# Altium schematic sheet 3) HALL EFFECT.SchDoc("3) HALL EFFECT")
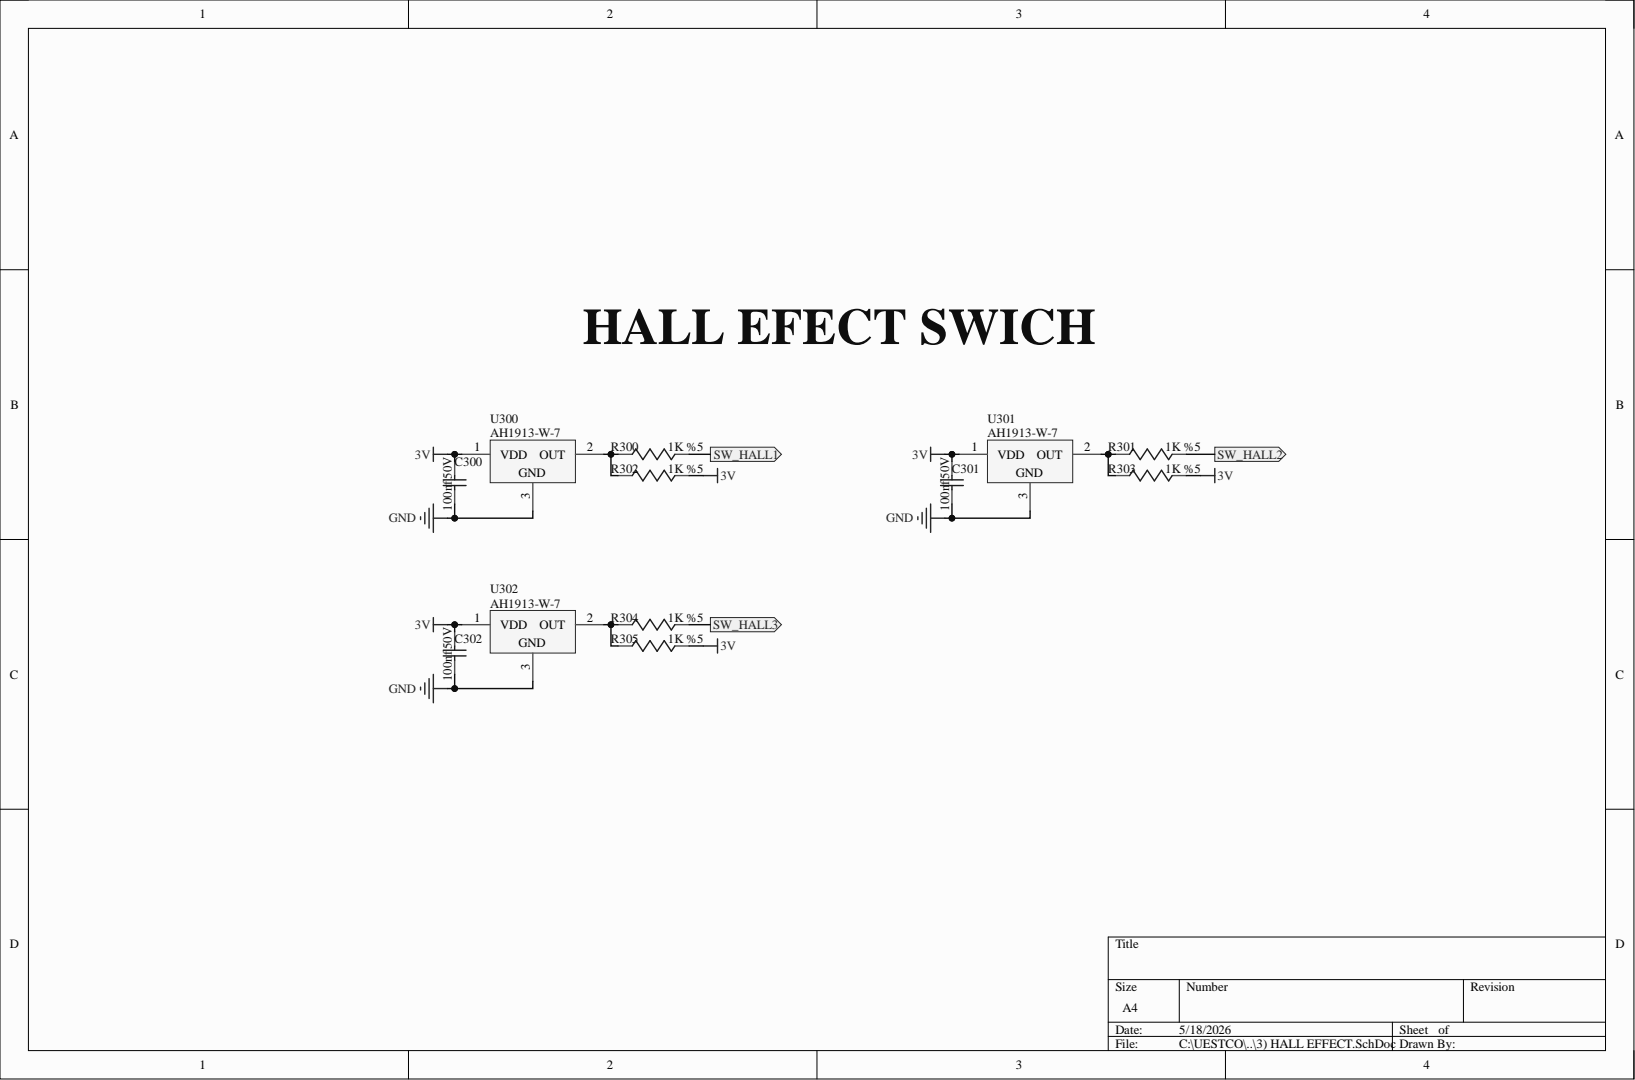
[diagram p0: 3) HALL EFFECT.SchDoc]

## Parts
C300  100nf 50V  [C0402]
C301  100nf 50V  [C0402]
C302  100nf 50V  [C0402]
R300  1K %5  [R0402]
R301  1K %5  [R0402]
R302  1K %5  [R0402]
R303  1K %5  [R0402]
R304  1K %5  [R0402]
R305  1K %5  [R0402]
U300  AH1913-W-7  [SOT23]
U301  AH1913-W-7  [SOT23]
U302  AH1913-W-7  [SOT23]

## Ports
SW_HALL1, SW_HALL2, SW_HALL3

## Nets
3V: C300.1 C301.1 C302.1 R302.1 R303.1 R305.1 U300.1 U301.1 U302.1
GND: C300.2 C301.2 C302.2 U300.3 U301.3 U302.3
SW_HALL1: R300.1 ; port SW_HALL1
SW_HALL2: R301.1 ; port SW_HALL2
SW_HALL3: R304.1 ; port SW_HALL3
NetR300_2: R300.2 R302.2 U300.2
NetR301_2: R301.2 R303.2 U301.2
NetR304_2: R304.2 R305.2 U302.2

## Library data of the parts
C300-C302 = CAP 100nf 50V %10 0402: CAP CER 0.1UF 50V X5R 0402; Manufacturer Part Number: CC0402KRX5R9BB104; Component Kind: Standard; Library Name: PCBGSM910G2.SCHLIB; Value: 100nf 50V
R300-R305 = RES 1K %5 1/16W 0402: RES SMD 1K OHM 5% 1/16W 0402; Manufacturer Part Number: RC0402JR-071KL; Component Kind: Standard; Library Name: PCBGSM910G2.SCHLIB; Value: 1K %5
U300-U302 = MAG SW AH1913-W-7: MAG SWITCH OMNIPOL SC59-3 T&R; Component Kind: Standard; Library Name: PCBGSD920G2B1.SCHLIB; Manufacturer Part Number: AH1913-W-7; Value: AH1913-W-7
